# Altium schematic sheet ZZWW_BX.SchDoc
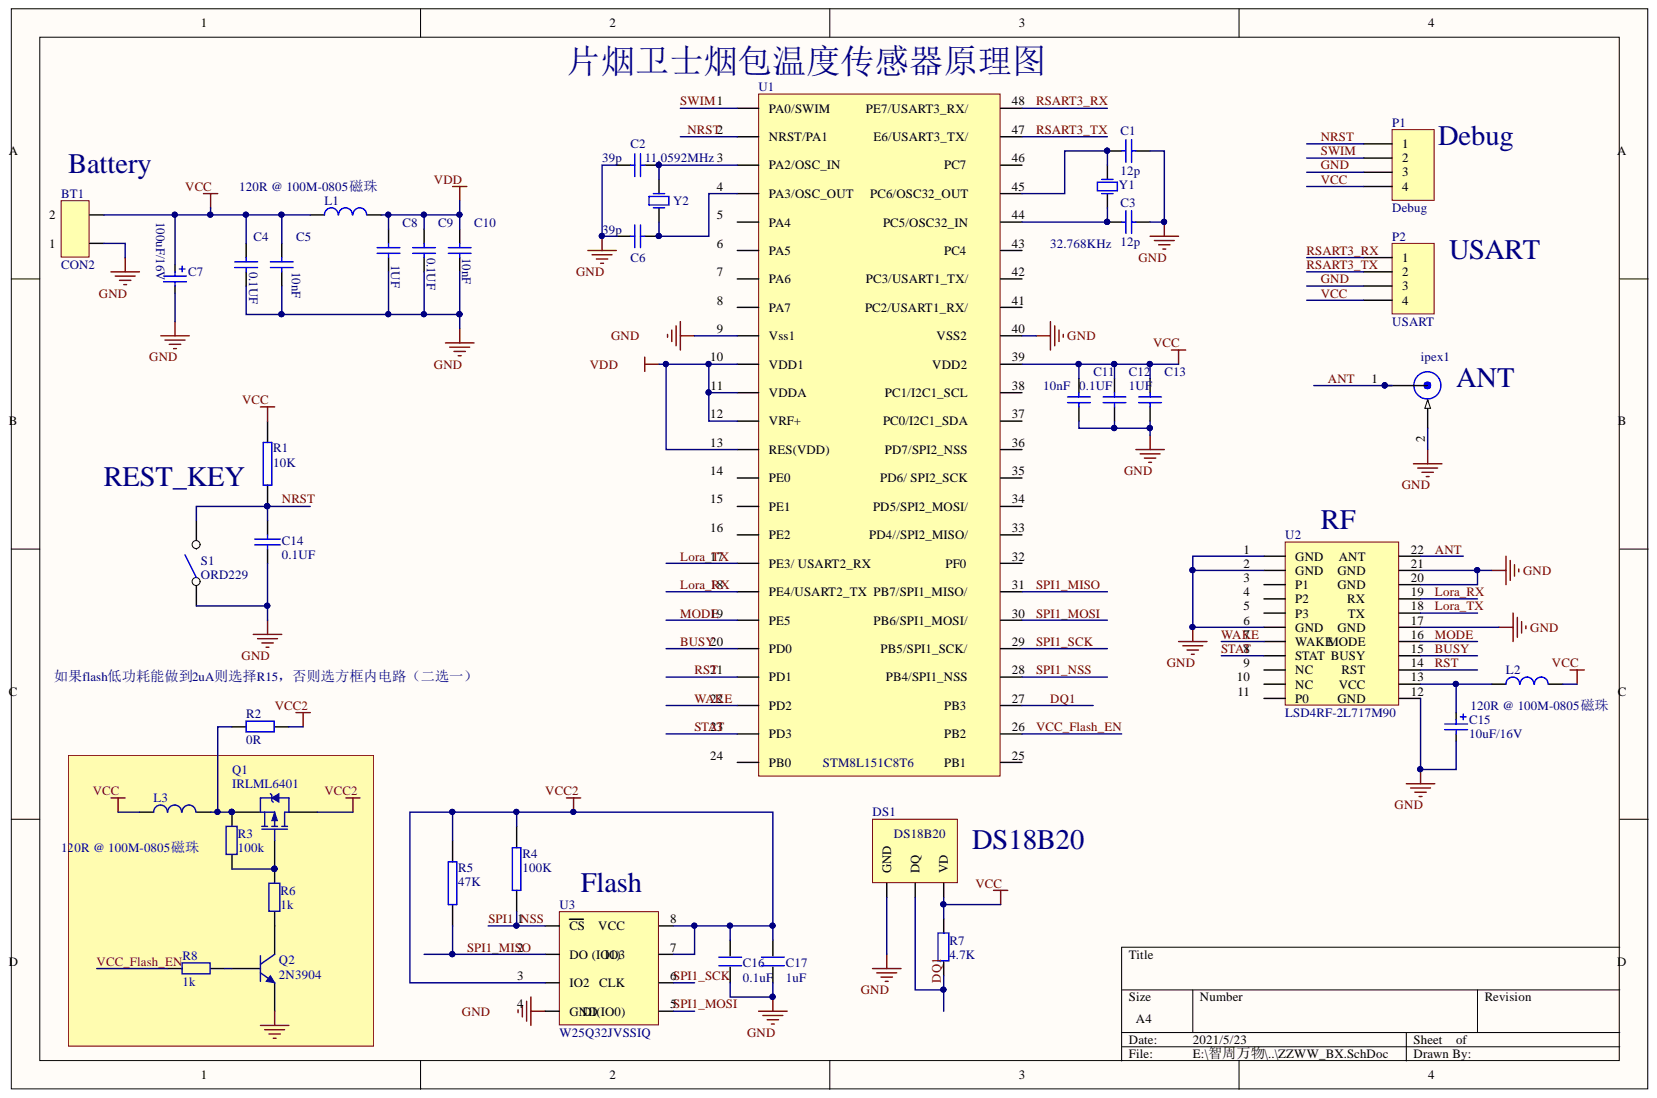
[diagram p0: ZZWW_BX.SchDoc]

## Parts
BT1  CON2  [IN OR OUT]
C1  12p  [0603]
C2  39p  [0603]
C3  12p  [0603]
C4  0.1UF  [0603]
C5  10nF  [0603]
C6  39p  [0603]
C7  100uF/16V  [CAP2-5]
C8  1UF  [0603]
C9  0.1UF  [0603]
C10  10nF  [0603]
C11  10nF  [0603]
C12  0.1UF  [0603]
C13  1UF  [0603]
C14  0.1UF  [0603]
C15  10uF/16V  [CAP2-5]
C16  0.1uF  [0603X]
C17  1uF  [0603X]
DS1  DS18B20  [XH2.54-3P]
ipex1  ipex  [ipex座]
L1  120R @ 100M-0805 磁珠  [0402B]
L2  120R @ 100M-0805 磁珠  [0402B]
L3  120R @ 100M-0805 磁珠  [0402B]
P1  Debug  [sip1-4]
P2  USART  [sip1-4]
Q1  IRLML6401  [SOT-23]
Q2  2N3904  [SOT-23]
R1  10K  [0603]
R2  0R  [0402B]
R3  100k  [0402B]
R4  100K  [0603]
R5  47K  [0603]
R6  1k  [0402B]
R7  4.7K  [0603]
R8  1k  [0402B]
S1  ORD229  [AXIAL-0.4-10]
U1  STM8L151C8T6
U2  LSD4RF-2L717M90
U3  W25Q32JVSSIQ
Y1  32.768KHz  [XTAL10]
Y2  11.0592MHz  [XTAL10]

## Nets
VCC2: C16.2 C17.2 Q1.3 R2.2 R4.2 R5.2 U3.3(IO2) U3.7 U3.8
VDD: C8.2 C9.2 C10.2 L1.2 U1.10(VDD1) U1.11(VDDA) U1.12(VRF+) U1.13(RES(VDD))
ANT: ipex1.1 U2.22
BUSY: U1.20(PD0) U2.15
DQ1: DS1.2 R7.1 U1.27
GND: BT1.1 C1.1 C2.1 C3.1 C4.1 C5.1 C6.1 C7.2 C8.1 C9.1 C10.1 C11.1 C12.1 C13.1 C14.2 C15.2 C16.1 C17.1 DS1.1 ipex1.2 P1.3 P2.3 Q2.2 S1.1 U1.9(Vss1) U1.40 U2.1(GND) U2.2(GND) U2.6(GND) U2.12 U2.17 U2.20 U2.21 U3.4(GND)
LORA_RX: U1.18(PE4/USART2_TX) U2.19
LORA_TX: U1.17(PE3/_USART2_RX) U2.18
MODE: U1.19(PE5) U2.16
NRST: C14.1 P1.1 R1.1 S1.2 U1.2(NRST/PA1)
RSART3_RX: P2.1 U1.48
RSART3_TX: P2.2 U1.47
RST: U1.21(PD1) U2.14
SPI1_MISO: R5.1 U1.31 U3.2(DO_(IO1))
SPI1_MOSI: U1.30 U3.5
SPI1_NSS: R4.1 U1.28 U3.1(CS)
SPI1_SCK: U1.29 U3.6
STAT: U1.23(PD3) U2.8(STAT)
SWIM: P1.2 U1.1(PA0/SWIM)
VCC: BT1.2 C4.2 C5.2 C7.1 C11.2 C12.2 C13.2 DS1.3 L1.1 L2.2 L3.1 P1.4 P2.4 R1.2 R7.2 U1.39
VCC_FLASH_EN: R8.1 U1.26
WAKE: U1.22(PD2) U2.7(WAKE)
NetC1_2: C1.2 U1.45 Y1.1
NetC2_2: C2.2 U1.3(PA2/OSC_IN) Y2.2
NetC3_2: C3.2 U1.44 Y1.2
NetC6_2: C6.2 U1.4(PA3/OSC_OUT) Y2.1
NetC15_1: C15.1 L2.1 U2.13
NetL3_2: L3.2 Q1.2 R2.1 R3.2
NetQ1_1: Q1.1 R3.1 R6.2
NetQ2_1: Q2.1 R8.2
NetQ2_3: Q2.3 R6.1

Not connected: U1 5(PA4) 6(PA5) 7(PA6) 8(PA7) 14(PE0) 15(PE1) 16(PE2) 24(PB0) 25 32 33 34 35 36 37 38 41 42 43 46; U2 3(P1) 4(P2) 5(P3) 9(NC) 10(NC) 11(P0)

## Library data of the parts
BT1 = CON2: 输入; Component Kind: Standard; Library Name: jcqschlib.SchLib
C1-C6 C8-C13 = Cap: 电容; Component Kind: Standard; LatestRevisionDate: 17-Jul-2002; LatestRevisionNote: Re-released for DXP Platform.; PackageReference: RAD-0.3; Published: 8-Jun-2000; Library Name: jcqschlib.SchLib; Publisher: Altium Limited
C7 C15 = Cap Pol1: 电解电容; Value: 100pF; Component Kind: Standard; LatestRevisionDate: 17-Jul-2002; LatestRevisionNote: Re-released for DXP Platform.; PackageReference: RB7.6-15; Published: 8-Jun-2000; Library Name: jcqschlib.SchLib; Publisher: Altium Limited
C14 = CAP: Capacitor; Component Kind: Standard; Library Name: Miscellaneous Devices.lib
C16 C17 = C: Component Kind: Standard; Library Name: Schlib1.SchLib
L1-L3 = INDUCTOR: HCB2012KF-121T20; Component Kind: Standard; Library Name: Miscellaneous Devices_protel99.lib
P1 P2 = MHDR1X4: Header, 4-Pin; Component Kind: Standard; LatestRevisionDate: 17-Jul-2002; LatestRevisionNote: Re-released for DXP Platform.; Library Name: Miscellaneous Connectors.IntLib; Publisher: Altium Limited
Q1 = PMOS-2: P-Channel Power MOSFET; Note: Pin 4 not drawn and electrically connected to Pin 2.; Component Kind: Standard; ComponentLink1Description: Manufacturer Link; ComponentLink1URL: http://www.irf.com/; DatasheetVersion: PD-9.390D; LatestRevisionDate: 17-Jul-2002; LatestRevisionNote: Re-released for DXP Platform.; Library Name: Si4463.SCHLIB; PackageDescription: TO, Thru-Hole, Vertical, Heatsink Mounted; 3 In-Line Leads; Pitch 2.54 mm; PackageReference: TO-220AB; PackageVersion: Feb-1997; Published: 8-Jun-2000; Simulation: IRF9510; Publisher: Altium Limited
Q2 = 2N3904: NPN General Purpose Amplifier; Component Kind: Standard; ComponentLink1Description: Manufacturer Link; ComponentLink1URL: http://www.fairchildsemi.com/; ComponentLink2Description: Datasheet; ComponentLink2URL: http://www.fairchildsemi.com/ds/2N%2F2N3904.pdf; DatasheetVersion: 1997; LatestRevisionDate: 15-Jan-2003; LatestRevisionNote: PCB Footprint 'BCY-W3/D4.7' replaced; Library Name: Si4463.SCHLIB; PackageDescription: TO, Flat Index; 3 In-Line, Axial Leads; Body Dia. 4.5mm; Leads 0.51 x 0.48 mm (max); PackageReference: TO-92A; PackageVersion: Sep-1998; Published: 8-Jun-2000; Signal Integrity: 2N3904; Simulation: 2N3904; Publisher: Altium Limited
R1 R4 R5 = RES: Component Kind: Standard; Library Name: 485主机通讯.lib
R2 R3 R7 = Res2: Resistor; Value: 1K; Component Kind: Standard; LatestRevisionDate: 17-Jul-2002; LatestRevisionNote: Re-released for DXP Platform.; Library Name: Miscellaneous Devices.IntLib; PackageReference: AXIAL-0.4; Published: 8-Jun-2000; Publisher: Altium Limited
  R2 R3: PackageDescription: Axial Device, Thru-Hole; 2 Leads; 0.4 in Pin Spacing; Signal Integrity: Res; Simulation: RESISTOR
R6 R8 = Res2: Resistor; Component Kind: Standard; LatestRevisionDate: 17-Jul-2002; LatestRevisionNote: Re-released for DXP Platform.; Library Name: Miscellaneous Devices.IntLib; PackageDescription: Axial Device, Thru-Hole; 2 Leads; 0.4 in Pin Spacing; PackageReference: AXIAL-0.4; Published: 8-Jun-2000; Signal Integrity: Res; Simulation: RESISTOR; Value: 1K; Publisher: Altium Limited
S1 = SW-SPST: 干簧管; Component Kind: Standard; Library Name: Miscellaneous Devices.lib
U1 = STM8L151C8T6: MCU; Component Kind: Standard; Library Name: jcqschlib.SchLib
U2 = LSD4RF-2L717M90: Lorawan模组; Component Kind: Standard; Library Name: jcqschlib.SchLib
U3 = W25Q32JVSSIQ: flash  32Mb SPI - Dual/Quad I/O; Component Kind: Standard; Library Name: jcqschlib.SchLib
Y1 Y2 = XTAL: Crystal Oscillator; Component Kind: Standard; LatestRevisionDate: 17-Jul-2002; LatestRevisionNote: Re-released for DXP Platform.; PackageReference: R38; Published: 8-Jun-2000; Simulation: XTAL; Library Name: jcqschlib.SchLib; Publisher: Altium Limited
DS1 = DS18B20: Component Kind: Standard; Library Name: jcqschlib.SchLib
ipex1 = ipex: Component Kind: Standard; Library Name: jcqschlib.SchLib
